# Altium schematic sheet Sheet1.SchDoc(Sheet1)
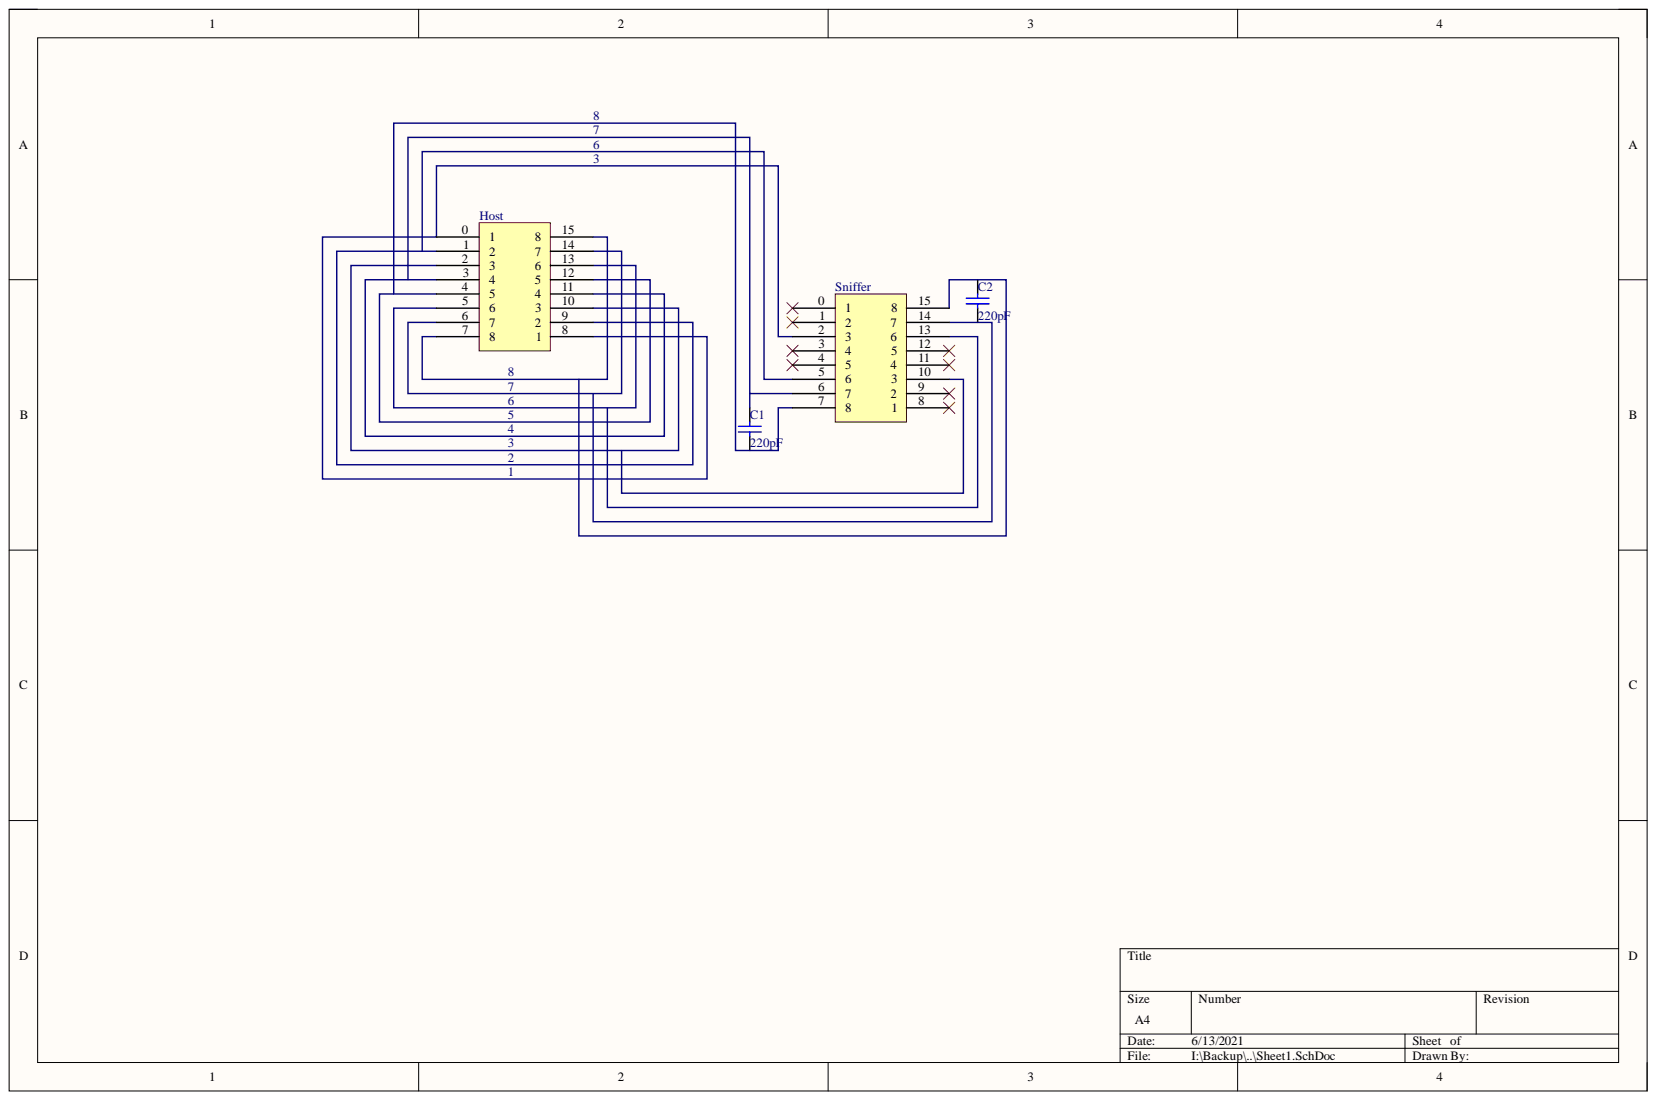
[diagram p0: Sheet1.SchDoc]

## Parts
C1  value 220pF  [cap]  (hidden comment: Cap)
C2  value 220pF  [cap]  (hidden comment: Cap)
Host  rj45_1x2  [rj45_1x2]  (value not drawn)
Sniffer  rj45_1x2  [rj45_1x2]  (value not drawn)

## Nets
NetC1_1: C1.1 Host.4 Host.12 Sniffer.7
NetC1_2: C1.2 Host.3 Host.11 Sniffer.6
NetC2_1: C2.1 Host.6 Host.14 Sniffer.14
NetC2_2: C2.2 Host.7 Host.15 Sniffer.15
NetHost_0: Host.0 Host.8 Sniffer.2
NetHost_1: Host.1 Host.9 Sniffer.5
NetHost_2: Host.2 Host.10 Sniffer.10
NetHost_5: Host.5 Host.13 Sniffer.13

Not connected: Sniffer 0 1 3 4 8 9 11 12

## Library data of the parts
C1 C2 = Cap: Capacitor; Component Kind: Standard; LatestRevisionDate: 17-Jul-2002; LatestRevisionNote: Re-released for DXP Platform.; Library Name: Miscellaneous Devices.IntLib; PackageDescription: Radial Cap, Thru-Hole; 2 Leads; 0.3 in Pin Spacing; PackageReference: RAD-0.3; Published: 8-Jun-2000; Signal Integrity: Cap; Simulation: CAP; Publisher: Altium Limited
Host Sniffer = rj45_1x2: Component Kind: Standard; Library Name: OTakuCal.SchLib
